# Altium schematic sheet KoruzaShieldv3.SchDoc
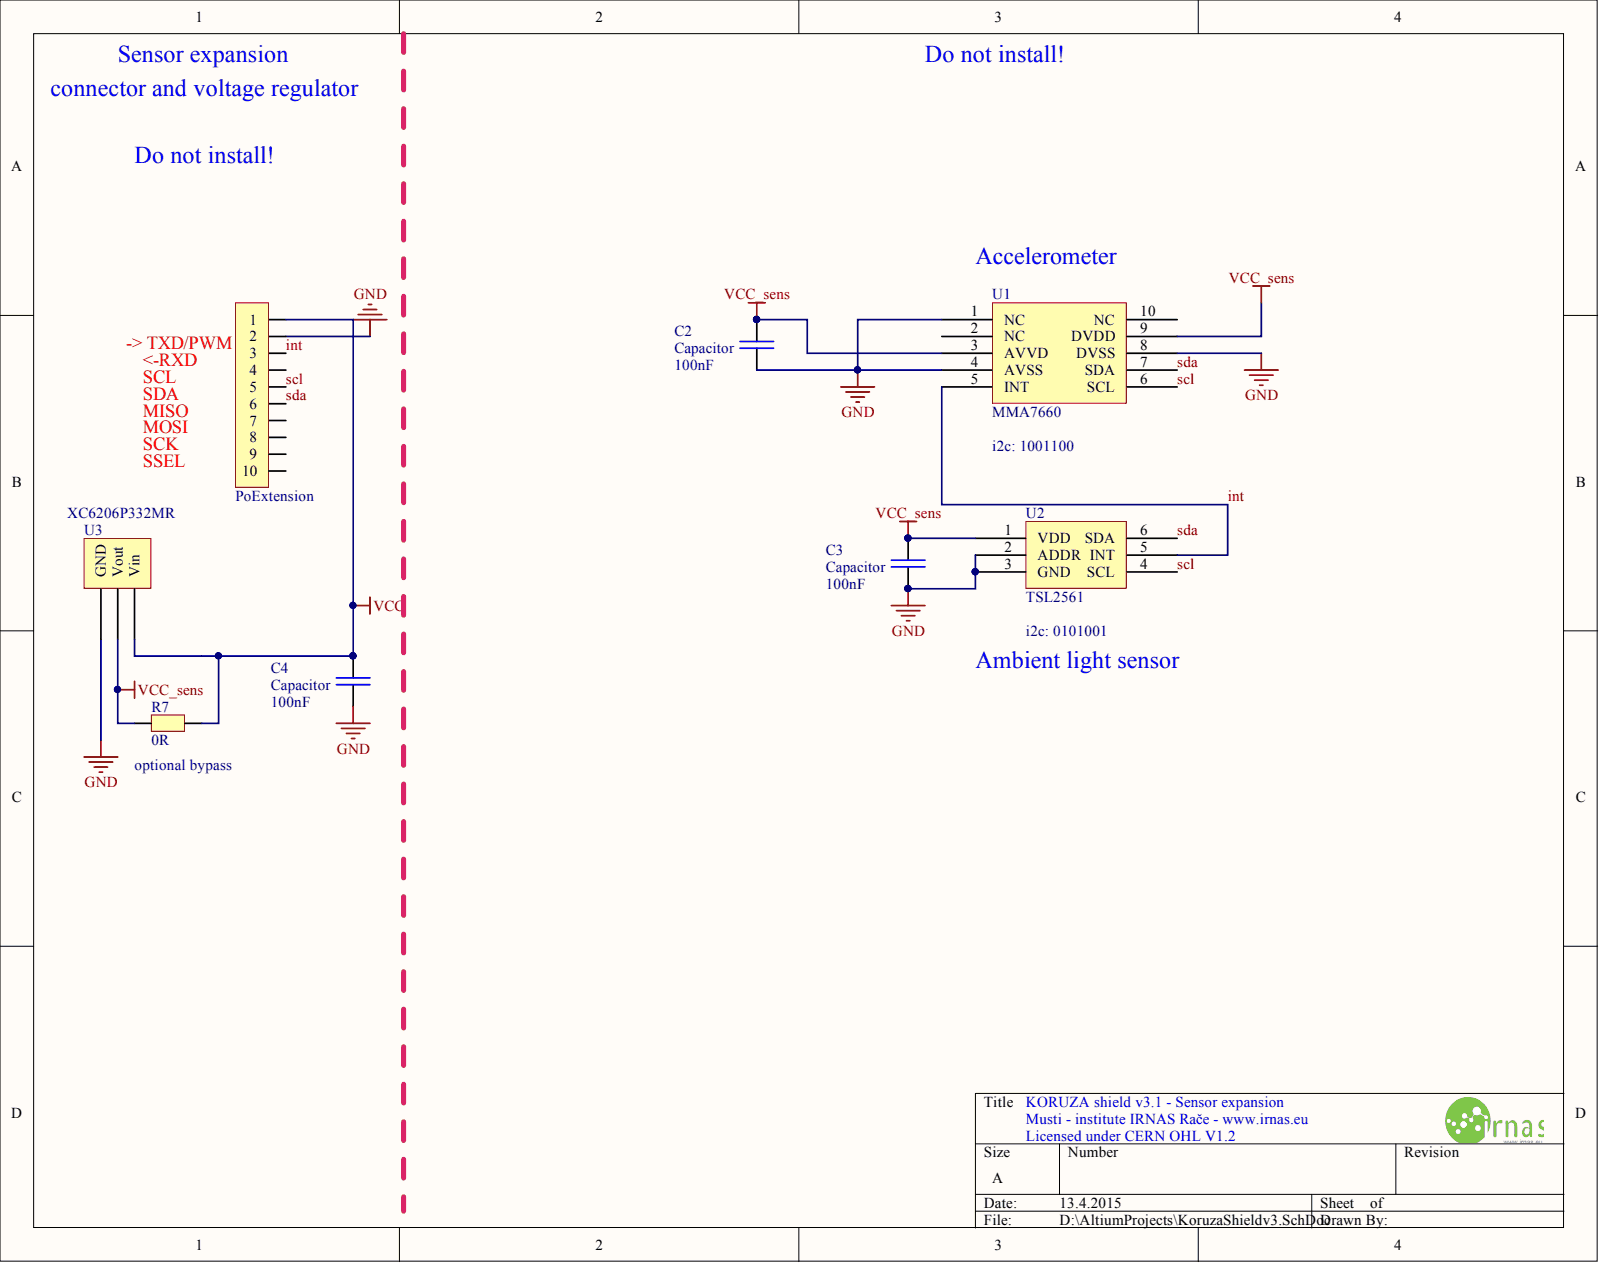
[diagram p0: KoruzaShieldv3.SchDoc]

## Parts
C2  Capacitor  value 100nF  [0603]
C3  Capacitor  value 100nF  [0603]
C4  Capacitor  value 100nF  [0603]
PoExtension  (no value)  [8-188275-0]
R7  0R  [RESC2012X06N]
U1  MMA7660  [MMA7660]
U2  TSL2561  [TSL2560]
U3  XC6206P332MR  [SOT23]

## Nets
GND: C2.2 C3.2 C4.2 PoExtension.2 U1.1(NC) U1.4(AVSS) U1.8(DVSS) U2.2(ADDR) U2.3(GND) U3.1
VCC: C4.1 PoExtension.1 R7.1 U3.3
VCC_SENS: C2.1 C3.1 R7.2 U1.3(AVVD) U1.9(DVDD) U2.1(VDD) U3.2
INT: PoExtension.3 U1.5(INT) U2.5(INT)
SCL: PoExtension.5 U1.6(SCL) U2.4(SCL)
SDA: PoExtension.6 U1.7(SDA) U2.6(SDA)

Not connected: PoExtension 4 7 8 9 10; U1 2(NC) 10(NC)

## Library data of the parts
C2-C4 = Capacitor: General capacitor; Component Kind: Standard; Library Name: GeneralComponents.SchLib
PoExtension = 1-215079-0: Micro-Match female PCB connector 10 Positions THT; Component Kind: Standard; Library Name: BorisMicroMatch.SchLib
R7 = Resistor: Resistor 0805 smd; Component Kind: Standard; Library Name: GeneralComponents.SchLib
U2 = TSL2560: TSL2560 light sensor; Component Kind: Standard; Library Name: GeneralComponents.SchLib
U3 = XC6206P332MR: Voltage regulator 3.3V 250mA; Component Kind: Standard; Library Name: GeneralComponents.SchLib
U1 = MMA7660: Component Kind: Standard; Description: MMA7660; Library Name: GeneralComponents.SchLib
